# Altium schematic sheet 27C513_EMU.SchDoc
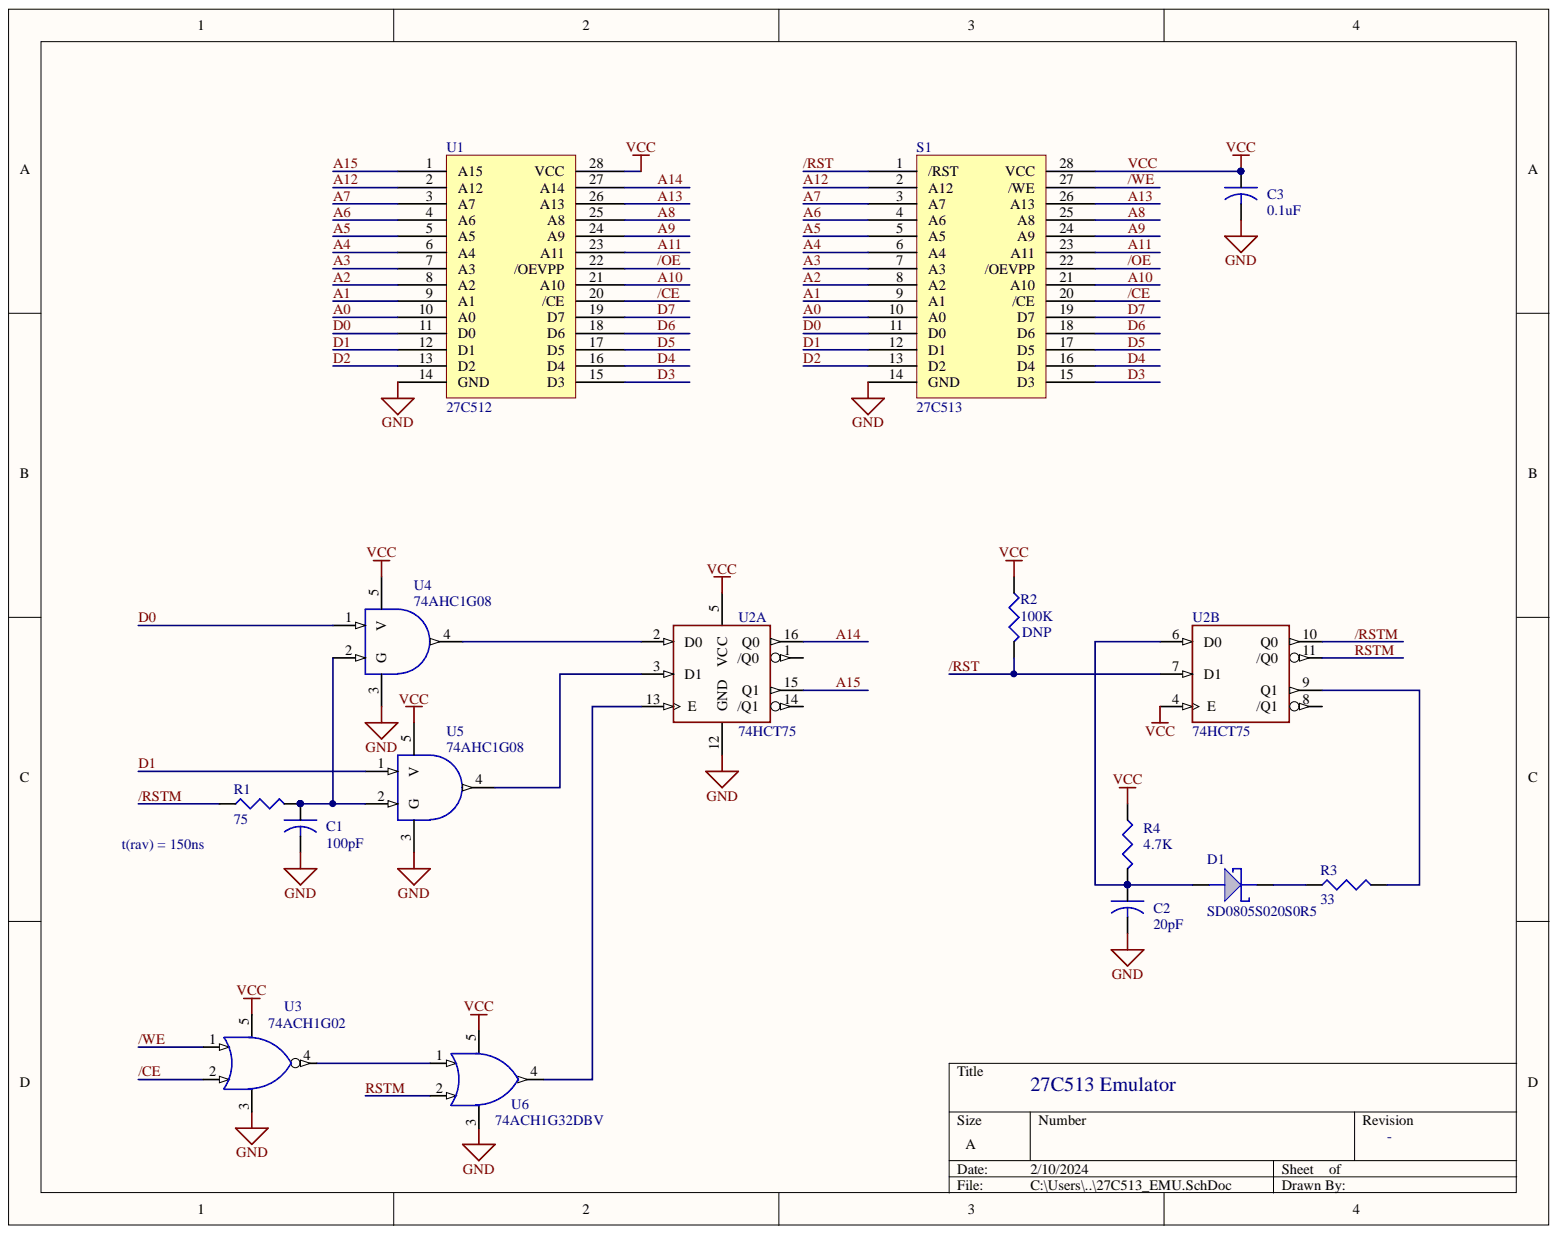
[diagram p0: 27C513_EMU.SchDoc]

## Parts
C1  100pF  [0402]
C2  20pF  [0402]
C3  0.1uF  [0805]
D1  SD0805S020S0R5  [0805-POL]
R1  75  [0402]
R2  100K  [0402]
R3  33  [0402]
R4  4.7K  [0402]
S1  27C513  [DIP28]
U1  27C512  [DIP28]
U2  74HCT75  [TSSOP-16]  (parts A,B)
U3  74ACH1G02  [SC70-5]
U4  74AHC1G08  [SC70-5]
U5  74AHC1G08  [SC70-5]
U6  74ACH1G32DBV  [SC70-5]

## Nets
GND: C1.2 C2.2 C3.2 S1.14(GND) U1.14(GND) U2.12(GND) U3.3 U4.3(G) U5.3 U6.3
/CE: S1.20(/CE) U1.20(/CE) U3.2
/OE: S1.22(/OEVPP) U1.22(/OEVPP)
/RST: R2.2 S1.1(/RST) U2.7(D1)
/RSTM: R1.1 U2.10(Q0)
/WE: S1.27(/WE) U3.1
A0: S1.10(A0) U1.10(A0)
A1: S1.9(A1) U1.9(A1)
A2: S1.8(A2) U1.8(A2)
A3: S1.7(A3) U1.7(A3)
A4: S1.6(A4) U1.6(A4)
A5: S1.5(A5) U1.5(A5)
A6: S1.4(A6) U1.4(A6)
A7: S1.3(A7) U1.3(A7)
A8: S1.25(A8) U1.25(A8)
A9: S1.24(A9) U1.24(A9)
A10: S1.21(A10) U1.21(A10)
A11: S1.23(A11) U1.23(A11)
A12: S1.2(A12) U1.2(A12)
A13: S1.26(A13) U1.26(A13)
A14: U1.27(A14) U2.16(Q0)
A15: U1.1(A15) U2.15(Q1)
D0: S1.11(D0) U1.11(D0) U4.1
D1: S1.12(D1) U1.12(D1) U5.1
D2: S1.13(D2) U1.13(D2)
D3: S1.15(D3) U1.15(D3)
D4: S1.16(D4) U1.16(D4)
D5: S1.17(D5) U1.17(D5)
D6: S1.18(D6) U1.18(D6)
D7: S1.19(D7) U1.19(D7)
RSTM: U2.11(/Q0) U6.2
VCC: C3.1 R2.1 R4.1 S1.28(VCC) U1.28(VCC) U2.4(E) U2.5(VCC) U3.5 U4.5(V) U5.5(V) U6.5
NetC1_1: C1.1 R1.2 U4.2 U5.2(G)
NetC2_1: C2.1 D1.2 R4.2 U2.6(D0)
NetD1_1: D1.1 R3.1
NetR3_2: R3.2 U2.9(Q1)
NetU2_2: U2.2(D0) U4.4
NetU2_3: U2.3(D1) U5.4
NetU2_13: U2.13(E) U6.4
NetU3_4: U3.4 U6.1

Not connected: U2 1(/Q0) 8(/Q1) 14(/Q1)

## Library data of the parts
C1 = CAP 0805: CAP, CER, 0402, 50V, 100pF; MFR: Murata; MPN: GCM1555C1H101FA16J; ALT-MFR: -; ALT-MPN: -; Component Kind: Standard; DNP: -; Library Name: Galaxy SCH-jem-1.08.SchLib
C2 = CAP 0805: CAP, CER, 0402, 50V, 20pF; MFR: Murata; MPN: GRM1555C1H200JA01D; ALT-MFR: -; ALT-MPN: -; Component Kind: Standard; DNP: -; Library Name: Galaxy SCH-jem-1.08.SchLib
C3 = CAP 0805: CAP, TANT, 0805, 50V, 0.1uF; MFR: Samsung EM; MPN: CL21B104KCFSFNE; ALT-MFR: -; ALT-MPN: -; Component Kind: Standard; DNP: -; Library Name: Galaxy SCH-jem-1.08.SchLib
D1 = DIODE_SCHOTTKY_SOD123: DIODE, SHTKY; MFR: Kyocera; ALT-MPN: -; Component Kind: Standard; DNP: -; MPN: SD0805S020S0R5; NOTES: -; NOTES2: -; PRI-MPN: -; Library Name: Galaxy SCH-jem-1.08.SchLib
R1 = R0805: RES, CHP, 0201, 1%, 100; MFR: Yageo; MPN: RC0402FR-0775RL; ALT-MPN: -; Component Kind: Standard; DNP: -; NOTES: -; NOTES2: -; PRI-MPN: -; Library Name: Galaxy SCH-jem-1.08.SchLib
R2 = R0805: RES, CHP, 0201, 1%, 100; MFR: Yageo; MPN: RC0402FR-07100KL; ALT-MPN: -; Component Kind: Standard; DNP: DNP; NOTES: -; NOTES2: -; PRI-MPN: -; Library Name: Galaxy SCH-jem-1.08.SchLib
R3 = R0805: RES, CHP, 0201, 1%, 100; MFR: Yageo; MPN: RC0402FR-0733RL; ALT-MPN: -; Component Kind: Standard; DNP: -; NOTES: -; NOTES2: -; PRI-MPN: -; Library Name: Galaxy SCH-jem-1.08.SchLib
R4 = R0805: RES, CHP, 0201, 1%, 100; MFR: Yageo; MPN: RC0402FR-074K7L; ALT-MPN: -; Component Kind: Standard; DNP: -; NOTES: -; NOTES2: -; PRI-MPN: -; Library Name: Galaxy SCH-jem-1.08.SchLib
S1 = 25C513: HDR, IC, DIP, 28p; MFR: Ares; MPN: 28-600-20; Component Kind: Standard; Library Name: Galaxy SCH-jem-1.08.SchLib
U1 = 25C512: IC, EPROM, 512Kb, x8, DIP; MFR: Any; MPN: 27C512-20; Component Kind: Standard; Library Name: Galaxy SCH-jem-1.08.SchLib
U2 = 74HCT75: IC, LGC, LTCH, DUAL; MFR: TI; MPN: CD74HC75PWR; ALT-MPN: -; Component Kind: Standard; DNP: -; NOTES: -; NOTES2: -; PRI-MPN: -; Library Name: Galaxy SCH-jem-1.08.SchLib
U3 = 74ACH1G02DBV+5V: IC,GATE,2IN,NOR,SNGL; MFR: TI; MPN: SN74AHC1G02DCKR; ALT-MPN: -; Component Kind: Standard; DNP: -; NOTES: -; NOTES2: -; PRI-MPN: -; Library Name: Galaxy SCH-jem-1.08.SchLib
U4 U5 = 74AHC1G08+5V: IC,GATE,2IN,AND,SNGL,; MFR: TI; MPN: SN74AHC1G08DCKRE4; ALT-MPN: -; Component Kind: Standard; DNP: -; NOTES: -; NOTES2: -; PRI-MPN: -; Library Name: Galaxy SCH-jem-1.08.SchLib
U6 = 74ACH1G32DBV+5V: IC,GATE,2IN,OR,SNGL; MFR: TI; MPN: SN74AHC1G32DCKR; ALT-MPN: -; Component Kind: Standard; DNP: -; NOTES: -; NOTES2: -; PRI-MPN: -; Library Name: Galaxy SCH-jem-1.08.SchLib
